# Altium schematic sheet S7CAS-PLEIADES-CARTE-MERE_P01_CLOCK.SchDoc
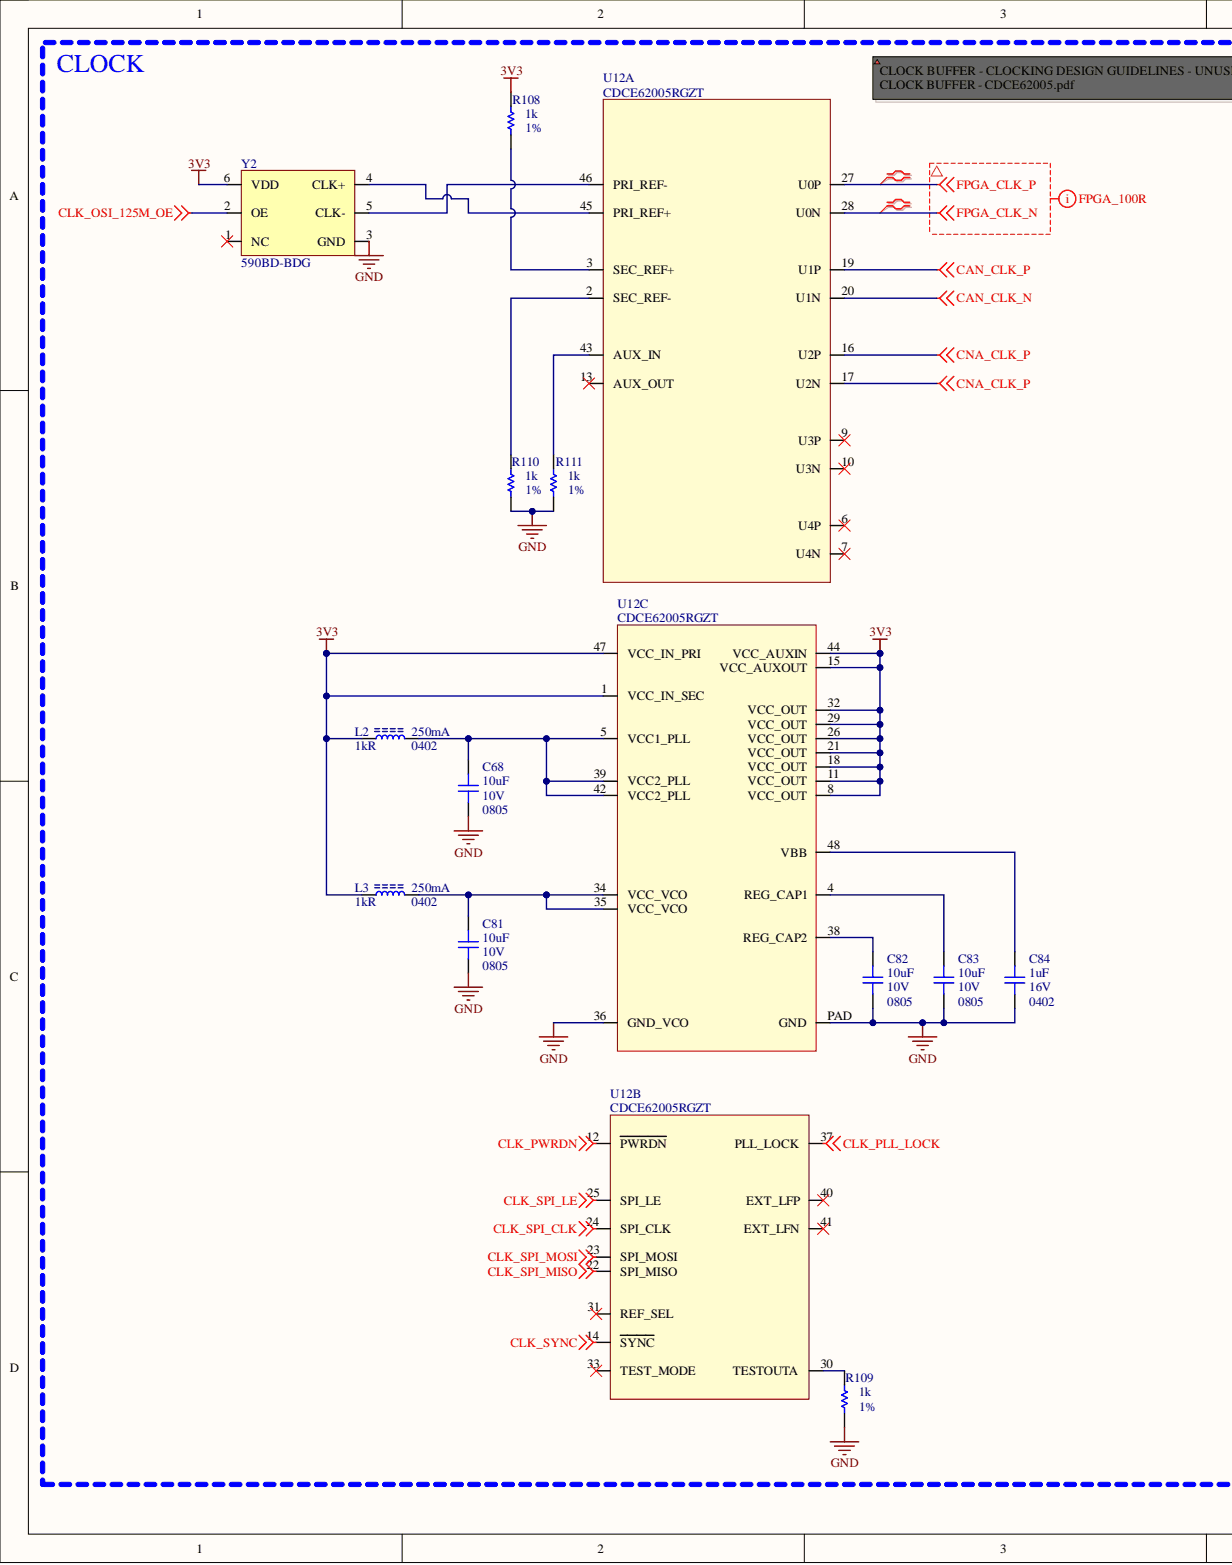
[diagram p0: S7CAS-PLEIADES-CARTE-MERE_P01_CLOCK.SchDoc, left]
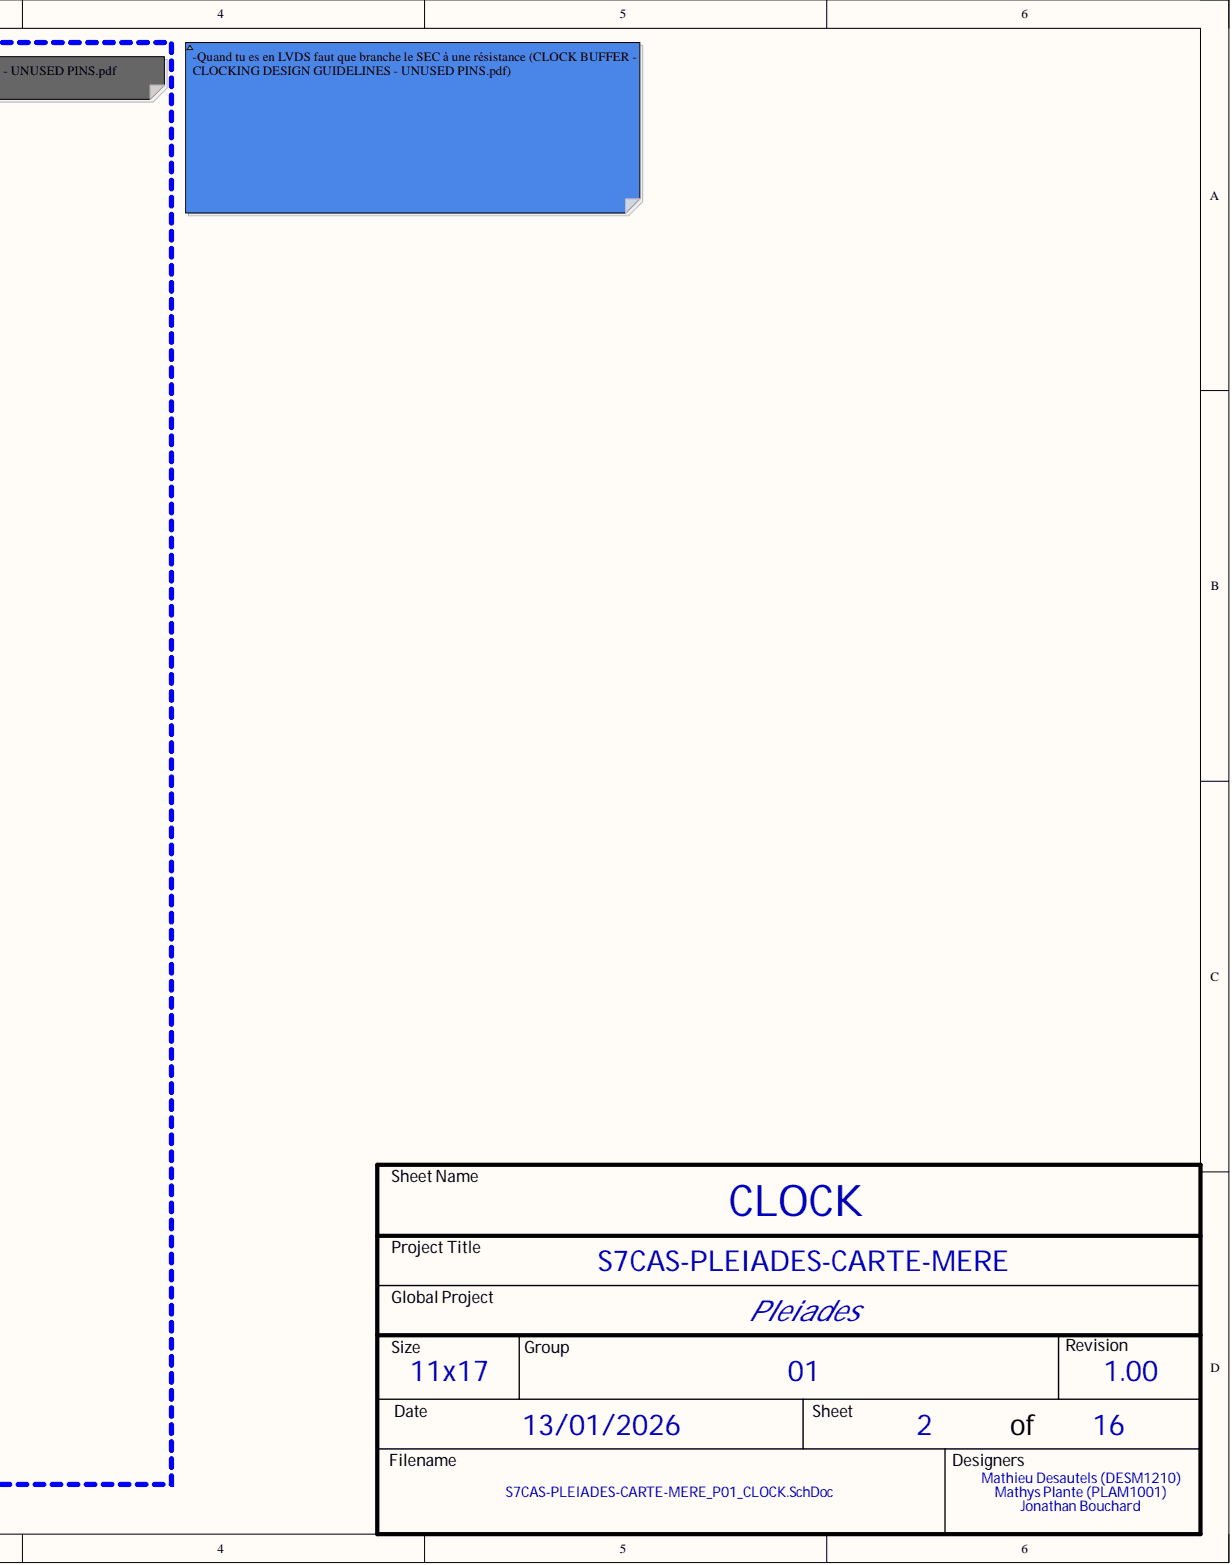
[diagram p1: S7CAS-PLEIADES-CARTE-MERE_P01_CLOCK.SchDoc, right]

## Parts
C68  value 10uF  Package: 0805  Voltage: 10V  [CAP_0805]  (hidden comment: CAP - 0805)
C81  value 10uF  Package: 0805  Voltage: 10V  [CAP_0805]  (hidden comment: CAP - 0805)
C82  value 10uF  Package: 0805  Voltage: 10V  [CAP_0805]  (hidden comment: CAP - 0805)
C83  value 10uF  Package: 0805  Voltage: 10V  [CAP_0805]  (hidden comment: CAP - 0805)
C84  value 1uF  Package: 0402  Voltage: 16V  [CAP_0402]  (hidden comment: CAP - 0402)
L2  value 1kR  Current: 250mA  Package: 0402  [FER_0402]  (hidden comment: FER - 0402)
L3  value 1kR  Current: 250mA  Package: 0402  [FER_0402]  (hidden comment: FER - 0402)
R108  value 1k  Precision: 1%  [RES_0402]  (hidden comment: RES - 0402)
R109  value 1k  Precision: 1%  [RES_0402]  (hidden comment: RES - 0402)
R110  value 1k  Precision: 1%  [RES_0402]  (hidden comment: RES - 0402)
R111  value 1k  Precision: 1%  [RES_0402]  (hidden comment: RES - 0402)
U12  CDCE62005RGZT  [TIM_CDCE62005RGZT]  (parts A,B,C)
Y2  590BD-BDG  [OSC_590BD-BDG]

## Nets
3V3: L2.2 L3.2 R108.2 U12.1(VCC_IN_SEC) U12.8(VCC_OUT) U12.11(VCC_OUT) U12.15(VCC_AUXOUT) U12.18(VCC_OUT) U12.21(VCC_OUT) U12.26(VCC_OUT) U12.29(VCC_OUT) U12.32(VCC_OUT) U12.44(VCC_AUXIN) U12.47(VCC_IN_PRI) Y2.6(VDD)
CAN_CLK_N: U12.20(U1N)
CAN_CLK_P: U12.19(U1P)
CLK_OSI_125M_OE: Y2.2(OE)
CLK_PLL_LOCK: U12.37(PLL_LOCK)
CLK_PWRDN: U12.12(PWRDN)
CLK_SPI_CLK: U12.24(SPI_CLK)
CLK_SPI_LE: U12.25(SPI_LE)
CLK_SPI_MISO: U12.22(SPI_MISO)
CLK_SPI_MOSI: U12.23(SPI_MOSI)
CLK_SYNC: U12.14(SYNC)
CNA_CLK_P: U12.16(U2P) U12.17(U2N)
FPGA_CLK_N: U12.28(U0N)
FPGA_CLK_P: U12.27(U0P)
GND: C68.1 C81.1 C82.1 C83.1 C84.1 R109.1 R110.1 R111.1 U12.36(GND_VCO) U12.PAD(GND) Y2.3(GND)
NetC68_2: C68.2 L2.1 U12.5(VCC1_PLL) U12.39(VCC2_PLL) U12.42(VCC2_PLL)
NetC81_2: C81.2 L3.1 U12.34(VCC_VCO) U12.35(VCC_VCO)
NetC82_2: C82.2 U12.38(REG_CAP2)
NetC83_2: C83.2 U12.4(REG_CAP1)
NetC84_2: C84.2 U12.48(VBB)
NetR108_1: R108.1 U12.3(SEC_REF+)
NetR109_2: R109.2 U12.30(TESTOUTA)
NetR110_2: R110.2 U12.2(SEC_REF-)
NetR111_2: R111.2 U12.43(AUX_IN)
NetU12_45: U12.45(PRI_REF+) Y2.4(CLK+)
NetU12_46: U12.46(PRI_REF-) Y2.5(CLK-)

Not connected: U12 6(U4P) 7(U4N) 9(U3P) 10(U3N) 13(AUX_OUT) 31(REF_SEL) 33(TEST_MODE) 40(EXT_LFP) 41(EXT_LFN); Y2 1(NC)

## Library data of the parts
C68 C81-C83 = CAP - 0805: 10 µF ±10% 10V Ceramic Capacitor X7R 0805 (2012 Metric); Mfg Part Number: GRM21BR71A106KA73L; Component Kind: Standard; Library Name: s7cas_lib2023.intlib; Part Number #1: GRM21BR71A106KA73L; Precision: 10%; CreatedBy: Jonathan Bouchard
C84 = CAP - 0402: Mfg Part Number: CL05A105KO5NNNC; Mfg: Samsung Electro-Mechanics; Precision: 10%; Component Kind: Standard; Description: CAP - 0402; Library Name: s7cas_lib2023.intlib; CreatedBy: Jonathan Bouchard
L2 L3 = FER - 0402: Mfg Part Number: BLM15HD102SN1D; Mfg: Murata Electronics; Component Kind: Standard; Description: FER - 0402; Library Name: s7cas_lib2023.intlib; CreatedBy: Jonathan Bouchard
R108-R111 = RES - 0402: 1 kOhms ±1% 0.1W, 1/10W Chip Resistor 0402 (1005 Metric) Automotive AEC-Q200 Thick Film; Mfg Part Number: ERJ-2RKF1001X; Mfg: Panasonic Electronic Components; Voltage: 50V; Component Kind: Standard; Library Name: s7cas_lib2023.intlib; Package: 0402; Part Number #1: ERJ-2RKF1001X; Power: 1/10W; CreatedBy: Jonathan Bouchard
U12 = TIM_CDCE62005RGZT: TIM - CLK SYNTH JITTER CLEANER 3:5 - QFN-48; Mfg: Texas Instruments; Voltage: 3 V ~ 3.6 V; Component Kind: Standard; HelpURL: http://www.ti.com/lit/ds/symlink/cdce62005.pdf; Library Name: s7cas_lib2023.intlib; Mfg Part Number: CDCE62005RGZT; Package: QFN-48; Supplier 1: Digikey; Supplier Part Number 1: 296-23947-1-ND; Temperature: -40°C ~ 85°C; CreatedBy: Jonathan Bouchard
Y2 = OSC_590BD-BDG: TIM - OSC PROG 125MHz ~ 214.999MHz LVDS 3.3V 7PPM EN/DS - 6-SMD; Manufacturer #1: Silicon Labs; Cryo: UNKNOWN; Current Rating: 100 mA (supply); GRAMS Lib Spec: 2.8; Note: Spécifié la fréquence lors de la commande; Polarized: Yes; Value: ±7ppm (freq Stability), ±20ppm (freq stability total); Voltage rating: 3.3V (supply); Assembly Type: SMD; Component Kind: Standard; HelpURL: https://www.silabs.com/documents/public/data-sheets/Si590-591.pdf; Library Name: s7cas_lib2023.intlib; Magnetic Material: UNKNOWN; Package: 6-SMD; Part Number #1: 590BD-BDG; Supplier 1: Digi-Key; Supplier Part Number 1: 590BD-BDG-ND; Temperature: -40°C ~ 85°C; CreatedBy/Date: from 590BD-CDG; VerifiedBy: Caroline Paulin / 3/10/2019
